# Altium schematic sheet Sheet_SwallFlyRemoter.SchDoc(Sheet_SwallFlyRemoter)
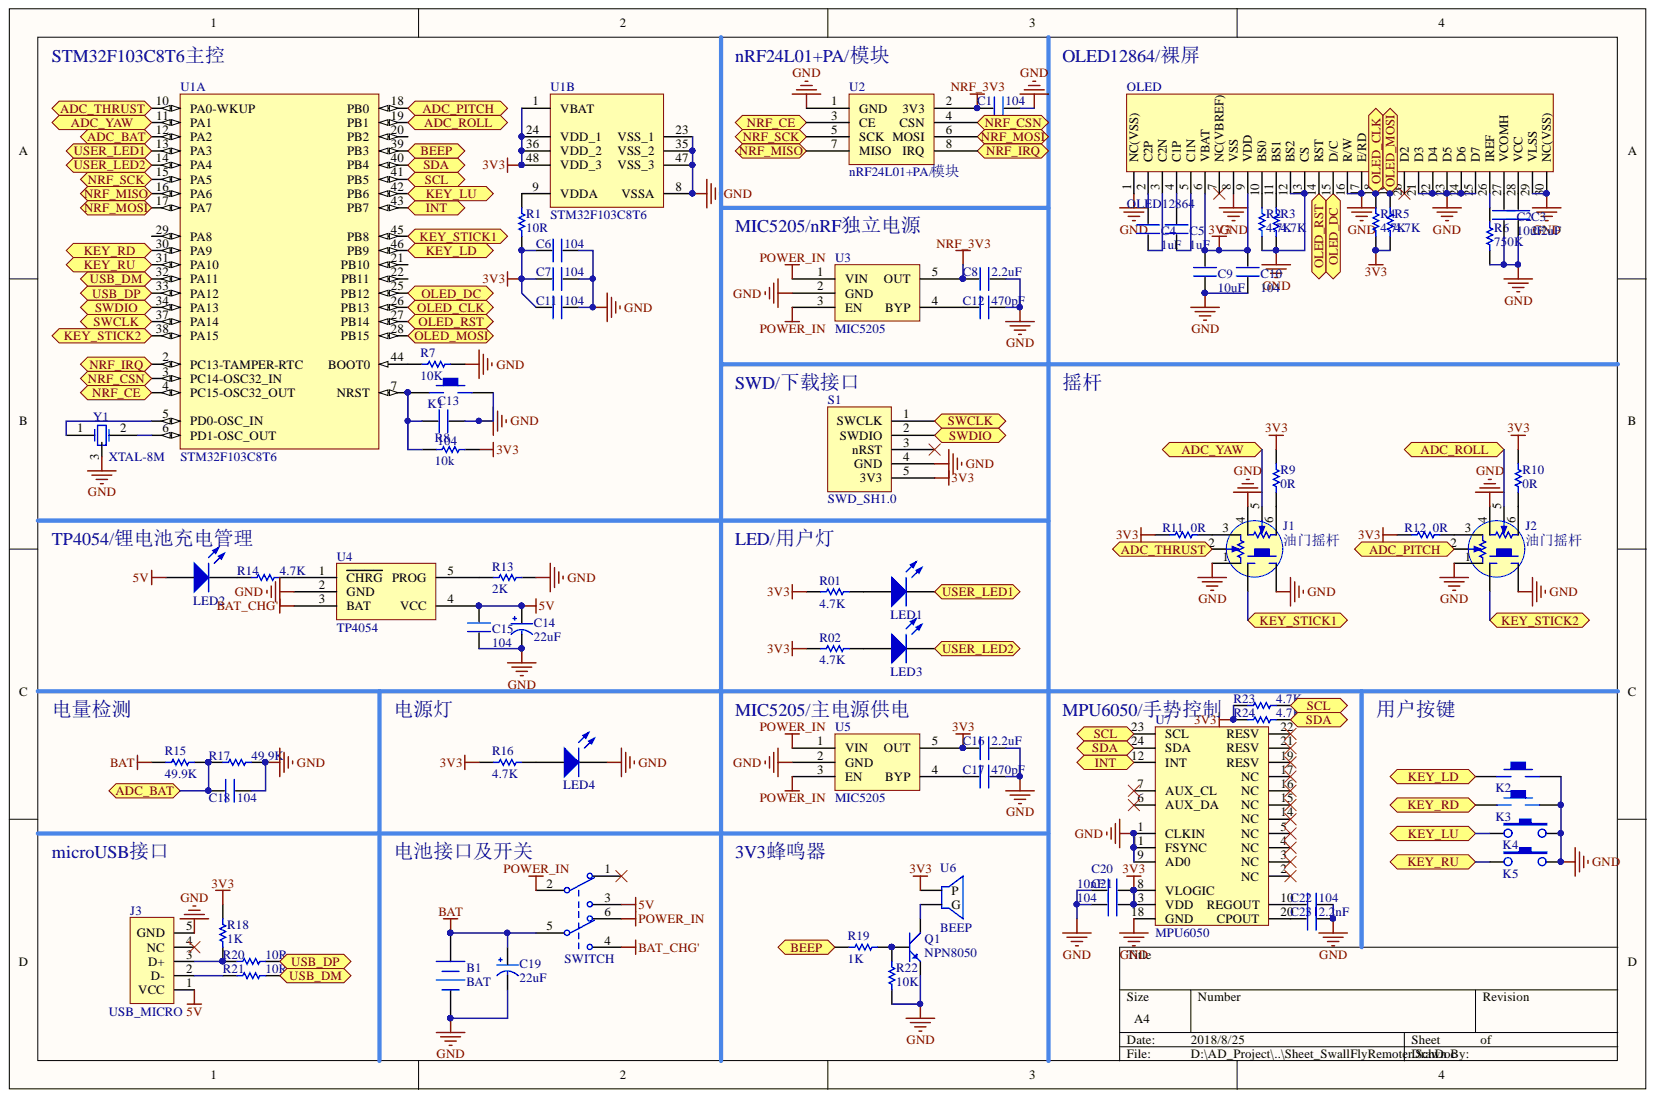
[diagram p0: Sheet_SwallFlyRemoter.SchDoc]

## Parts
B1  BAT  [BAT]
C1  104  [0603C]
C2  10uF  [0603C]
C3  2.2uF  [0603C]
C4  1uF  [0603C]
C5  1uF  [0603C]
C6  104  [0603C]
C7  104  [0603C]
C8  2.2uF  [0603C]
C9  10uF  [0603C]
C10  104  [0603C]
C11  104  [0603C]
C12  470pF  [0603C]
C13  104  [0603c]
C14  22uF  [1206TCAP]
C15  104  [0603C]
C16  2.2uF  [0603C]
C17  470pF  [0603C]
C18  104  [0603C]
C19  22uF  [1206TCAP]
C20  10nF  [0603C]
C21  104  [0603C]
C22  104  [0603C]
C23  2.2nF  [0603C]
J1  油门摇杆  [JOYSTICK]
J2  油门摇杆  [JOYSTICK]
J3  USB_MICRO  [USB_micro]
K1  KEY  [KEY_MINI]  (value not drawn)
K2  KEY  [KEY]
K3  KEY  [KEY]
K4  侧脚6*6*X微动按键  [侧脚6*6*X微动按键]  (value not drawn)
K5  侧脚6*6*X微动按键  [侧脚6*6*X微动按键]  (value not drawn)
LED1  0805LED  [0805LED]  (value not drawn)
LED2  0805LED  [0805LED]  (value not drawn)
LED3  0805LED  [0805LED]  (value not drawn)
LED4  0805LED  [0805LED]  (value not drawn)
OLED  OLED12864  [oled12864]
Q1  NPN8050  [NPN8050 SOT23R]
R01  4.7K  [0603r]
R1  10R  [0603r]
R02  4.7K  [0603r]
R2  4.7K  [0603r]
R3  4.7K  [0603r]
R4  4.7K  [0603r]
R5  4.7K  [0603r]
R6  750K  [0603r]
R7  10K  [0603r]
R8  10k  [0603r]
R9  0R  [0603r]
R10  0R  [0603r]
R11  0R  [0603r]
R12  0R  [0603r]
R13  2K  [0603r]
R14  4.7K  [0603r]
R15  49.9K  [0603r]
R16  4.7K  [0603r]
R17  49.9K  [0603r]
R18  1K  [0603r]
R19  1K  [0603r]
R20  10R  [0603r]
R21  10R  [0603r]
R22  10K  [0603r]
R23  4.7K  [0603r]
R24  4.7K  [0603r]
S1  SWD_SH1.0  [SWD_SH1.0]
SWITCH  单刀双掷开关  [单刀双掷开关]  (value not drawn)
U1  STM32F103C8T6  [STM-LQFP48_N]  (parts A,B)
U2  nRF24L01+PA/模块  [NRF24L01+PA/模块]
U3  MIC5205  [SOT23-5]
U4  TP4054  [SOT23-5]
U5  MIC5205  [SOT23-5]
U6  BEEP  [BELL-SMALL]
U7  MPU6050  [QFN-24_4x4x05P]
Y1  XTAL-8M  [XTAL_8M]

## Ports
ADC_BAT, ADC_PITCH, ADC_ROLL, ADC_THRUST, ADC_YAW, BEEP, INT, KEY_LD, KEY_LU, KEY_RD, KEY_RU, KEY_STICK1, KEY_STICK2, NRF_CE, NRF_CSN, NRF_IRQ, NRF_MISO, NRF_MOSI, NRF_SCK, OLED_CLK, OLED_DC, OLED_MOSI, OLED_RST, SCL, SDA, SWCLK, SWDIO, USB_DM, USB_DP, USER_LED1, USER_LED2

## Nets
3V3: C6.1 C7.1 C9.2 C10.2 C11.1 C16.2 C20.2 C21.2 OLED.6(VBAT) OLED.9(VDD) R01.1 R1.1 R02.1 R4.1 R5.1 R8.2 R9.2 R10.2 R11.1 R12.1 R16.1 R18.1 R23.1 R24.1 S1.5(3V3) U1.1(VBAT) U1.24(VDD_1) U1.36(VDD_2) U1.48(VDD_3) U5.5(OUT) U6.1 U7.8(VLOGIC) U7.13(VDD)
5V: C14.1 C15.2 J3.1(VCC) LED2.1 SWITCH.3 U4.4(VCC)
BAT: B1.1 C19.1 R15.1 SWITCH.5
BAT_CHG': SWITCH.4 U4.3(BAT)
GND: B1.2 C1.2 C2.1 C3.1 C6.2 C7.2 C8.1 C9.1 C10.1 C11.2 C12.1 C13.2 C14.2 C15.1 C16.1 C17.1 C18.2 C19.2 C20.1 C21.1 C22.2 C23.2 J1.1 J1.4 J1.J1 J2.1 J2.4 J2.J1 J3.5(GND) K1.2 K2.2 K3.2 K4.1 K5.1 LED4.2 OLED.1(NC(VSS)) OLED.8(VSS) OLED.12(BS2) OLED.13(CS) OLED.16(R/W) OLED.17(E/RD) OLED.21(D3) OLED.22(D4) OLED.23(D5) OLED.24(D6) OLED.25(D7) OLED.29(VLSS) OLED.30(NC(VSS)) Q1.1 R2.1 R3.1 R6.1 R7.2 R13.2 R17.2 R22.1 S1.4(GND) U1.8(VSSA) U1.23(VSS_1) U1.35(VSS_2) U1.47(VSS_3) U2.1(GND) U3.2(GND) U4.2(GND) U5.2(GND) U7.1(CLKIN) U7.9(AD0) U7.11(FSYNC) U7.18(GND) Y1.3
NRF_3V3: C1.1 C8.2 U2.2(3V3) U3.5(OUT)
POWER_IN: SWITCH.2 SWITCH.6 U3.1(VIN) U3.3(EN) U5.1(VIN) U5.3(EN)
ADC_BAT: C18.1 R15.2 R17.1 U1.12(PA2) ; port ADC_BAT
ADC_PITCH: J2.2 U1.18(PB0) ; port ADC_PITCH
ADC_ROLL: J2.5 U1.19(PB1) ; port ADC_ROLL
ADC_THRUST: J1.2 U1.10(PA0-WKUP) ; port ADC_THRUST
ADC_YAW: J1.5 U1.11(PA1) ; port ADC_YAW
BEEP: R19.1 U1.39(PB3) ; port BEEP
INT: U1.43(PB7) U7.12(INT) ; port INT
KEY_LD: K2.1 U1.46(PB9) ; port KEY_LD
KEY_LU: K4.2 U1.42(PB6) ; port KEY_LU
KEY_RD: K3.1 U1.30(PA9) ; port KEY_RD
KEY_RU: K5.2 U1.31(PA10) ; port KEY_RU
KEY_STICK1: J1.J2 U1.45(PB8) ; port KEY_STICK1
KEY_STICK2: J2.J2 U1.38(PA15) ; port KEY_STICK2
NRF_CE: U1.4(PC15-OSC32_OUT) U2.3(CE) ; port NRF_CE
NRF_CSN: U1.3(PC14-OSC32_IN) U2.4(CSN) ; port NRF_CSN
NRF_IRQ: U1.2(PC13-TAMPER-RTC) U2.8(IRQ) ; port NRF_IRQ
NRF_MISO: U1.16(PA6) U2.7(MISO) ; port NRF_MISO
NRF_MOSI: U1.17(PA7) U2.6(MOSI) ; port NRF_MOSI
NRF_SCK: U1.15(PA5) U2.5(SCK) ; port NRF_SCK
OLED_CLK: OLED.18(D0) R4.2 U1.26(PB13) ; port OLED_CLK
OLED_DC: OLED.15(D/C) U1.25(PB12) ; port OLED_DC
OLED_MOSI: OLED.19(D1) R5.2 U1.28(PB15) ; port OLED_MOSI
OLED_RST: OLED.14(RST) U1.27(PB14) ; port OLED_RST
SCL: R23.2 U1.41(PB5) U7.23(SCL) ; port SCL
SDA: R24.2 U1.40(PB4) U7.24(SDA) ; port SDA
SWCLK: S1.1(SWCLK) U1.37(PA14) ; port SWCLK
SWDIO: S1.2(SWDIO) U1.34(PA13) ; port SWDIO
USB_DM: R21.2 U1.32(PA11) ; port USB_DM
USB_DP: R20.2 U1.33(PA12) ; port USB_DP
USER_LED1: LED1.2 U1.13(PA3) ; port USER_LED1
USER_LED2: LED3.2 U1.14(PA4) ; port USER_LED2
NetC2_2: C2.2 OLED.27(VCOMH)
NetC3_2: C3.2 OLED.28(VCC)
NetC4_1: C4.1 OLED.3(C2N)
NetC4_2: C4.2 OLED.2(C2P)
NetC5_1: C5.1 OLED.5(C1N)
NetC5_2: C5.2 OLED.4(C1P)
NetC12_2: C12.2 U3.4(BYP)
NetC13_1: C13.1 K1.1 R8.1 U1.7(NRST)
NetC17_2: C17.2 U5.4(BYP)
NetC22_1: C22.1 U7.10(REGOUT)
NetC23_1: C23.1 U7.20(CPOUT)
NetJ1_3: J1.3 R11.2
NetJ1_6: J1.6 R9.1
NetJ2_3: J2.3 R12.2
NetJ2_6: J2.6 R10.1
NetJ3_2: J3.2(D-) R21.1
NetJ3_3: J3.3(D+) R18.2 R20.1
NetLED1_1: LED1.1 R01.2
NetLED2_2: LED2.2 R14.1
NetLED3_1: LED3.1 R02.2
NetLED4_1: LED4.1 R16.2
NetOLED_10: OLED.10(BS0) R2.2
NetOLED_11: OLED.11(BS1) R3.2
NetOLED_26: OLED.26(IREF) R6.2
NetQ1_2: Q1.2 R19.2 R22.2
NetQ1_3: Q1.3 U6.2
NetR1_2: R1.2 U1.9(VDDA)
NetR7_1: R7.1 U1.44(BOOT0)
NetR13_1: R13.1 U4.5(PROG)
NetR14_2: R14.2 U4.1(CHRG)
NetU1_5: U1.5(PD0-OSC_IN) Y1.1
NetU1_6: U1.6(PD1-OSC_OUT) Y1.2

Not connected: J3 4(NC); OLED 7(NC(VBREF)) 20(D2); S1 3(nRST); SWITCH 1; U1 20(PB2) 21(PB10) 22(PB11) 29(PA8); U7 2(NC) 3(NC) 4(NC) 5(NC) 6(AUX_DA) 7(AUX_CL) 14(NC) 15(NC) 16(NC) 17(NC) 19(RESV) 21(RESV) 22(RESV)

## Library data of the parts
C1-C12 C15-C18 C20-C23 = 0603C: Component Kind: Standard; Library Name: Mlia.SchLib
C13 = 0603c: Component Kind: Standard; Library Name: Mlia.SchLib
C14 C19 = TCAP: Component Kind: Standard; Library Name: Mlia.SchLib
J1 J2 = 摇杆: Description: 摇杆; Component Kind: Standard; Library Name: Mlia.SchLib
R01 R1 R02 R2-R7 R9-R24 = 0603R: Component Kind: Standard; Library Name: Mlia.SchLib
R8 = 0603r: Component Kind: Standard; Library Name: Mlia.SchLib
U1 = STM32F103C8T6: ARM Cortex-M3 32-bit MCU, 64 KB Flash, 20 KB Internal RAM, 37 I/Os, 48-pin LQFP, -40 to 85 degC, Tray; Supply Voltage(Vcc) min (V): 2; Operating Frequency(F) (Processor speed) (MHz): 72; Internal RAM Size (kB): 20; I/Os (High Current): 37; FLASH Size (Prog) (kB): 64; A/D Converter: 2x12-bit (10 Channel); Supplier Part Number 5: 511-STM32F103C8T6; Supplier Part Number 4: 57P1222; Supplier Part Number 3: 59M2182; Supplier Part Number 2: 1447637; Supplier Part Number 1: 497-6063-ND; Supplier 5: Mouser; Supplier 4: Newark; Supplier 3: Newark; Supplier 2: Farnell; Supplier 1: Digi-Key; PartNumber: STM32F103C8T6; Packing: Tray; PackageVersion: Rev. 6, 06/2013; PackageReference: LQFP48; PackageDescription: 48-Pin Low Profile Quad Flat Package, 7 x 7 mm Body, 0.5 mm Pitch; Mounting Technology: Surface Mount; Library Name: STMicroelectronics STM32 F1.IntLib; DatasheetVersion: Rev. 16; Core: ARM Cortex-M3; ComponentLink3URL: http://www.st.com/st-web-ui/static/active/en/resource/technical/document/datasheet/DM00058181.pdf; ComponentLink3Description: Package Specification; ComponentLink2URL: http://www.st.com/st-web-ui/static/active/en/resource/technical/document/datasheet/CD00161566.pdf; ComponentLink2Description: Datasheet; ComponentLink1URL: http://www.st.com/web/en/home.html; ComponentLink1Description: Manufacturer URL; Component Kind: Standard
B1 = BAT: Component Kind: Standard; Library Name: Mlia.SchLib
J3 = USB_MICRO: Component Kind: Standard; Library Name: Mlia.SchLib
K1-K3 = KEY: Component Kind: Standard; Library Name: Mlia.SchLib
K4 K5 = 侧脚6*6*X微动按键: Component Kind: Standard; Library Name: Mlia.SchLib
LED1-LED4 = 0805LED: Component Kind: Standard; Library Name: Mlia.SchLib
OLED = OLED12864: Component Kind: Standard; Library Name: Mlia.SchLib
Q1 = NPN8050: Component Kind: Standard; Library Name: Mlia.SchLib
S1 = SWD_SH1.0: Component Kind: Standard; Library Name: Mlia.SchLib
SWITCH = 单刀双掷开关: Component Kind: Standard; Library Name: Mlia.SchLib
U2 = nRF24L01+PA/模块: Component Kind: Standard; Library Name: Mlia.SchLib
U3 U5 = MIC5205: Component Kind: Standard; Library Name: Mlia.SchLib
U4 = TP4054: Component Kind: Standard; Library Name: Mlia.SchLib
U6 = BEEP: Component Kind: Standard; Library Name: Mlia.SchLib
U7 = MPU6050: Component Kind: Standard; Library Name: Mlia.SchLib
Y1 = XTAL-8M: Component Kind: Standard; Library Name: Mlia.SchLib
